# Altium schematic sheet Debug_USB.SchDoc
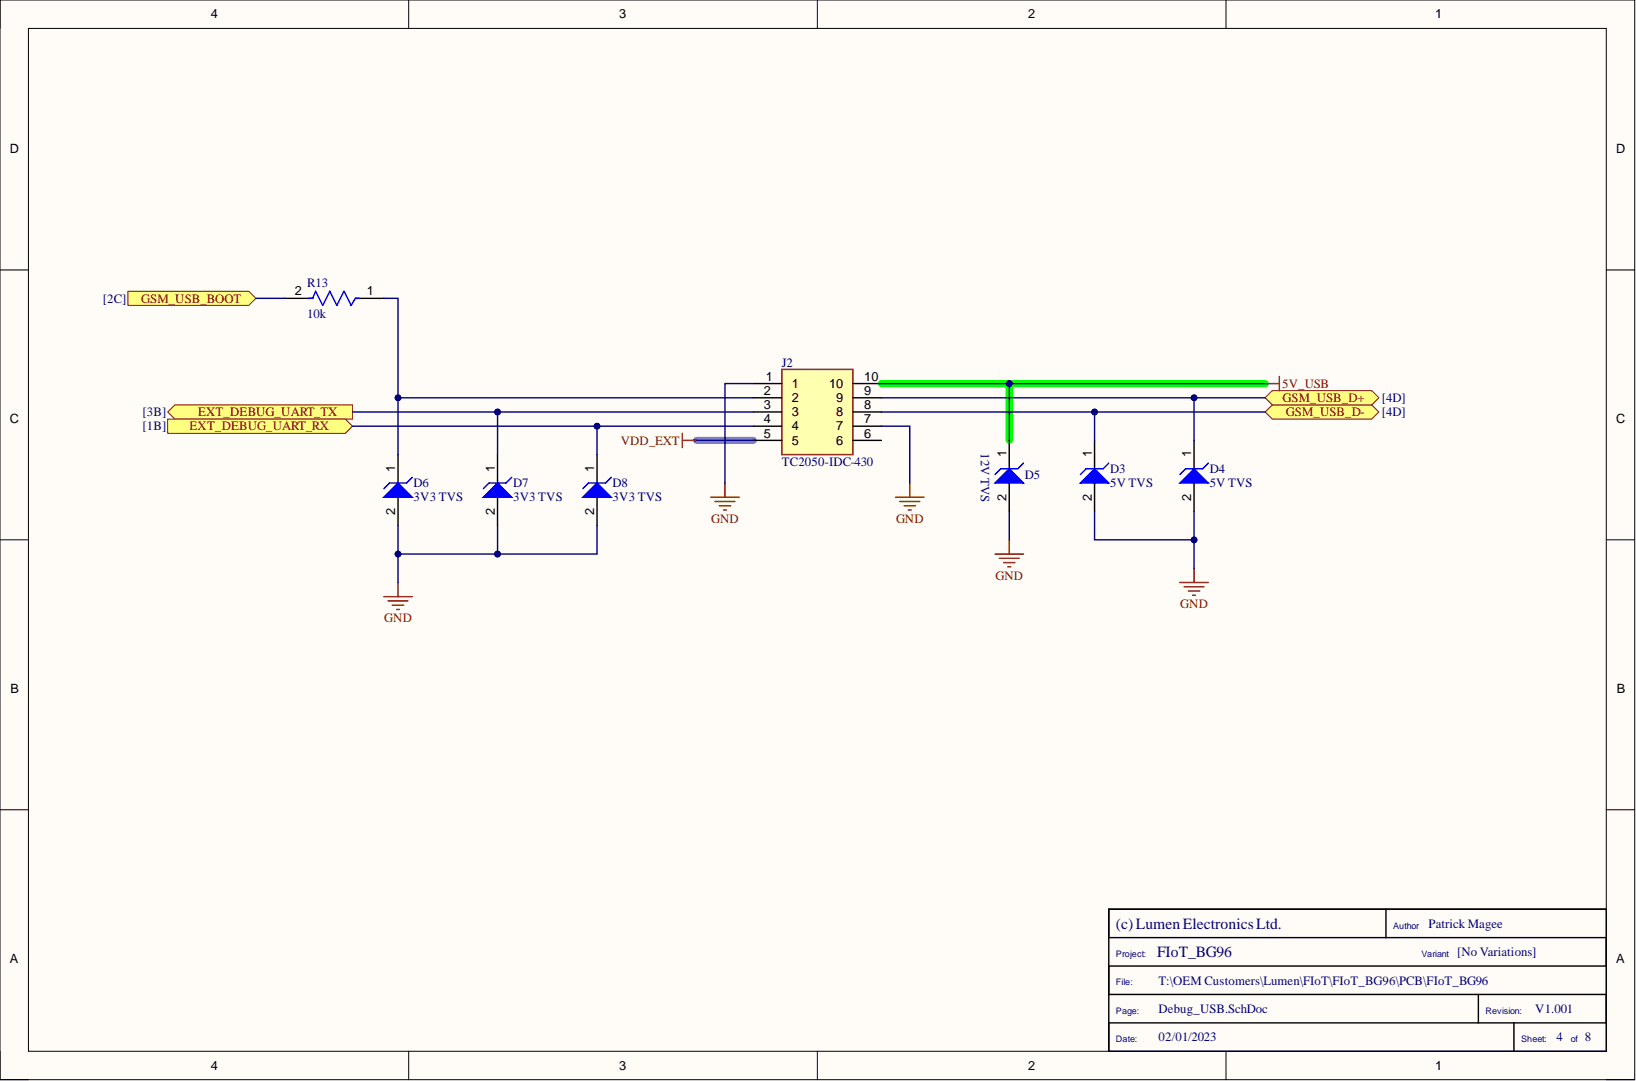
[diagram p0: Debug_USB.SchDoc]

## Parts
D3  value 5V TVS  [ONSC-SOD-923-2-514AA-01_V]  (hidden comment: ESD9L5.0ST5G)
D4  value 5V TVS  [ONSC-SOD-923-2-514AA-01_V]  (hidden comment: ESD9L5.0ST5G)
D5  SD12C.TCT  [CR_SD05_SEM-L]  (value not drawn)
D6  value 3V3 TVS  [ONSC-SOD-923-2-514AA-01_V]  (hidden comment: ESD9X3.3ST5G)
D7  value 3V3 TVS  [ONSC-SOD-923-2-514AA-01_V]  (hidden comment: ESD9X3.3ST5G)
D8  value 3V3 TVS  [ONSC-SOD-923-2-514AA-01_V]  (hidden comment: ESD9X3.3ST5G)
J2  TC2050-IDC-430  [TC2050-IDC_Lumen]  (no BOM)
R13  Resistance: 10k  [FP-CRCW0402-e3-MFG]  (hidden comment: 0402WGF1002TCE)

## Ports
EXT_DEBUG_UART_RX, EXT_DEBUG_UART_TX, GSM_USB_BOOT, GSM_USB_D+, GSM_USB_D-

## Nets
5V_USB: D5.1 J2.10
GND: D3.2 D4.2 D5.2 D6.2 D7.2 D8.2 J2.1 J2.7
VDD_EXT: J2.5
EXT_DEBUG_UART_RX: D8.1 J2.4 ; port EXT_DEBUG_UART_RX
EXT_DEBUG_UART_TX: D7.1 J2.3 ; port EXT_DEBUG_UART_TX
GSM_USB_BOOT: R13.2 ; port GSM_USB_BOOT
GSM_USB_D+: D4.1 J2.9 ; port GSM_USB_D+
GSM_USB_D-: D3.1 J2.8 ; port GSM_USB_D-
NetD6_1: D6.1 J2.2 R13.1

Not connected: J2 6

## Library data of the parts
D3 D4 = ESD9L5.0ST5G: Transient Voltage Suppressor, ESD Protection Diode with Ultra-Low Capacitance, 2-Pin SOD-923, Pb-Free, Tape and Reel; Manufacturer: ON Semiconductor; C Max (pF): 0.9; Direction: Unidirectional; IR Max (uA): 1; MFG Name: onsemi; V(BR) Min (V): 5.4; VRWM Max (V): 5; Component Kind: Standard; ComponentLink1Description: Manufacturer URL; ComponentLink1URL: http://www.onsemi.com/; ComponentLink2Description: Datasheet; ComponentLink2URL: http://www.onsemi.cn/pub_link/Collateral/ESD9L-D.PDF; ComponentLink3Description: Package Specification; ComponentLink3URL: http://www.onsemi.com/pub_link/Collateral/514AB.PDF; DatasheetVersion: Rev. 5; JLC Footprint: SOD-923; JLCPCB Part #: C82326; Library Name: FIoT_BG96.SCHLIB; Manufacturer Part Number: ESD9L5.0ST5G; Manufacturer_Name: On Semiconductor; Manufacturer_Part_Number: ESD9L5.0ST5G; MFG Part Number: ESD9L5.0ST5G; Mounting Technology: Surface Mount; Number of Lines: 1; PackageDescription: 2-Pin SOD, Body 0.8 x 0.6 mm; PackageReference: SOD-923-2-514AB-01; PackageVersion: Rev. C, 03/2011; Packing: Tape and Reel; PartNumber: ESD9L5.0ST5G; RoHS: Pb-Free; Supplier 1: Digi-Key; Supplier 2: Mouser; Supplier 3: LCSC; Supplier Part Number 1: ESD9L5.0ST5GOSCT-ND; Supplier Part Number 2: 863-ESD9L5.0ST5G; Supplier Part Number 3: C82326
D5 = SD12C.TCT: TVS DIODE 12VWM 24VC SOD323; Mfr_Name: Semtech; Type: DEV; Component Kind: Standard; Library Name: FIoT_BG96.SCHLIB; Manufacturer_Part_Number: SD12C.TCT; RefDes: RefDes; Supplier 1: Digi-Key; Supplier 2: Mouser; Supplier 3: LCSC; Supplier Part Number 1: SD12C.TCTCT-ND; Supplier Part Number 2: 947-SD12C.TCT; Supplier Part Number 3: C85198; Copyright: Copyright (C) 2022 Ultra Librarian. All rights reserved.
D6-D8 = ESD9X3.3ST5G: Transient Voltage Suppressor, Micro-Packaged Diode for ESD Protection, 2-Pin SOD-923, Pb-Free, Tape and Reel; Manufacturer: On Semiconductor; Direction: Unidirectional; IR Max (uA): 2.5; Number of Lines: 1; V(BR) Min (V): 5; VRWM Max (V): 3.3; Component Kind: Standard; ComponentLink1Description: Manufacturer URL; ComponentLink1URL: http://www.onsemi.com/; ComponentLink2Description: Datasheet; ComponentLink2URL: http://www.onsemi.cn/pub_link/Collateral/ESD9X3.3ST5G-D.PDF; ComponentLink3Description: Package Specification; ComponentLink3URL: http://www.onsemi.com/pub_link/Collateral/514AA.PDF; DatasheetVersion: Rev. 7; JLC Footprint: SOD-923; JLCPCB Part #: C130456; Library Name: FIoT_BG96.SCHLIB; Manufacturer Part Number: ESD9X3.3ST5G; MFG Name: ON Semiconductor; MFG Part Number: ESD9X3.3ST5G; Mounting Technology: Surface Mount; PackageDescription: 2-Pin SOD, Body 0.8 x 0.6 mm; PackageReference: SOD-923-2-514AA-01; PackageVersion: Rev. E, 03/2011; Packing: Tape and Reel; PartNumber: ESD9X3.3ST5G; RoHS: Pb-Free; Supplier 1: Farnell; Supplier 2: Mouser; Supplier 3: LCSC; Supplier Part Number 1: 2627963; Supplier Part Number 2: 863-ESD9X3.3ST5G; Supplier Part Number 3: C130456
J2 = TC2050-IDC-430: Connector; Manufacturer_Name: Tag Connect; Datasheet Link: https://datasheet.datasheetarchive.com/originals/distributors/Datasheets_SAMA/8a7b9a42a753a5cbaca4256ee093e59c.pdf; Library Name: FIoT_BG96.SCHLIB; Manufacturer_Part_Number: TC2050-IDC-430
R13 = 0402WGF1002TCE: Res Thick Film 0402 10K Ohm 1% 0.063W; Manufacturer: Vishay Dale; Manufacturer Part Number: CRCW04021K00FKED; Max Power Dissipation: 63mW; Temperature Coefficient: 100ppm/°C; Voltage Rating: 50V; Case Code (Imperial): 0402; Case Code (Metric): 1005; Case/Package: 0402; Component Kind: Standard; Composition: Thick Film; Contact Plating: Tin; Depth: 0.5mm; Features: Automotive AEC-Q200; Height: 0.35mm; JLC Footprint: R0402; JLCPCB Part #: Not available with JLC; Lead Free: Lead Free; Length: 1mm; Library Name: FIoT_BG96.SCHLIB; Max Operating Temperature: 155°C; MFG Name: Vishay Dale; MFG Part Number: CRCW04021K00FKED; Military Standard: Not; Min Operating Temperature: -55°C; Mount: Surface Mount; Number of Pins: 2; Number of Terminations: 2; Package Quantity: 10; Packaging: Tape and Reel; Power Rating: 63mW; Radiation Hardening: No; Supplier 1: Mouser; Supplier 2: LCSC; Supplier Part Number 1: 303-0402WGF1002TCE; Supplier Part Number 2: C25744; Termination: SMD/SMT; Tolerance: 1%; Voltage Rating (DC): 50V; Weight: 2.3E-05oz; Width: 0.5mm
